# Altium schematic sheet stm32f7.SchDoc
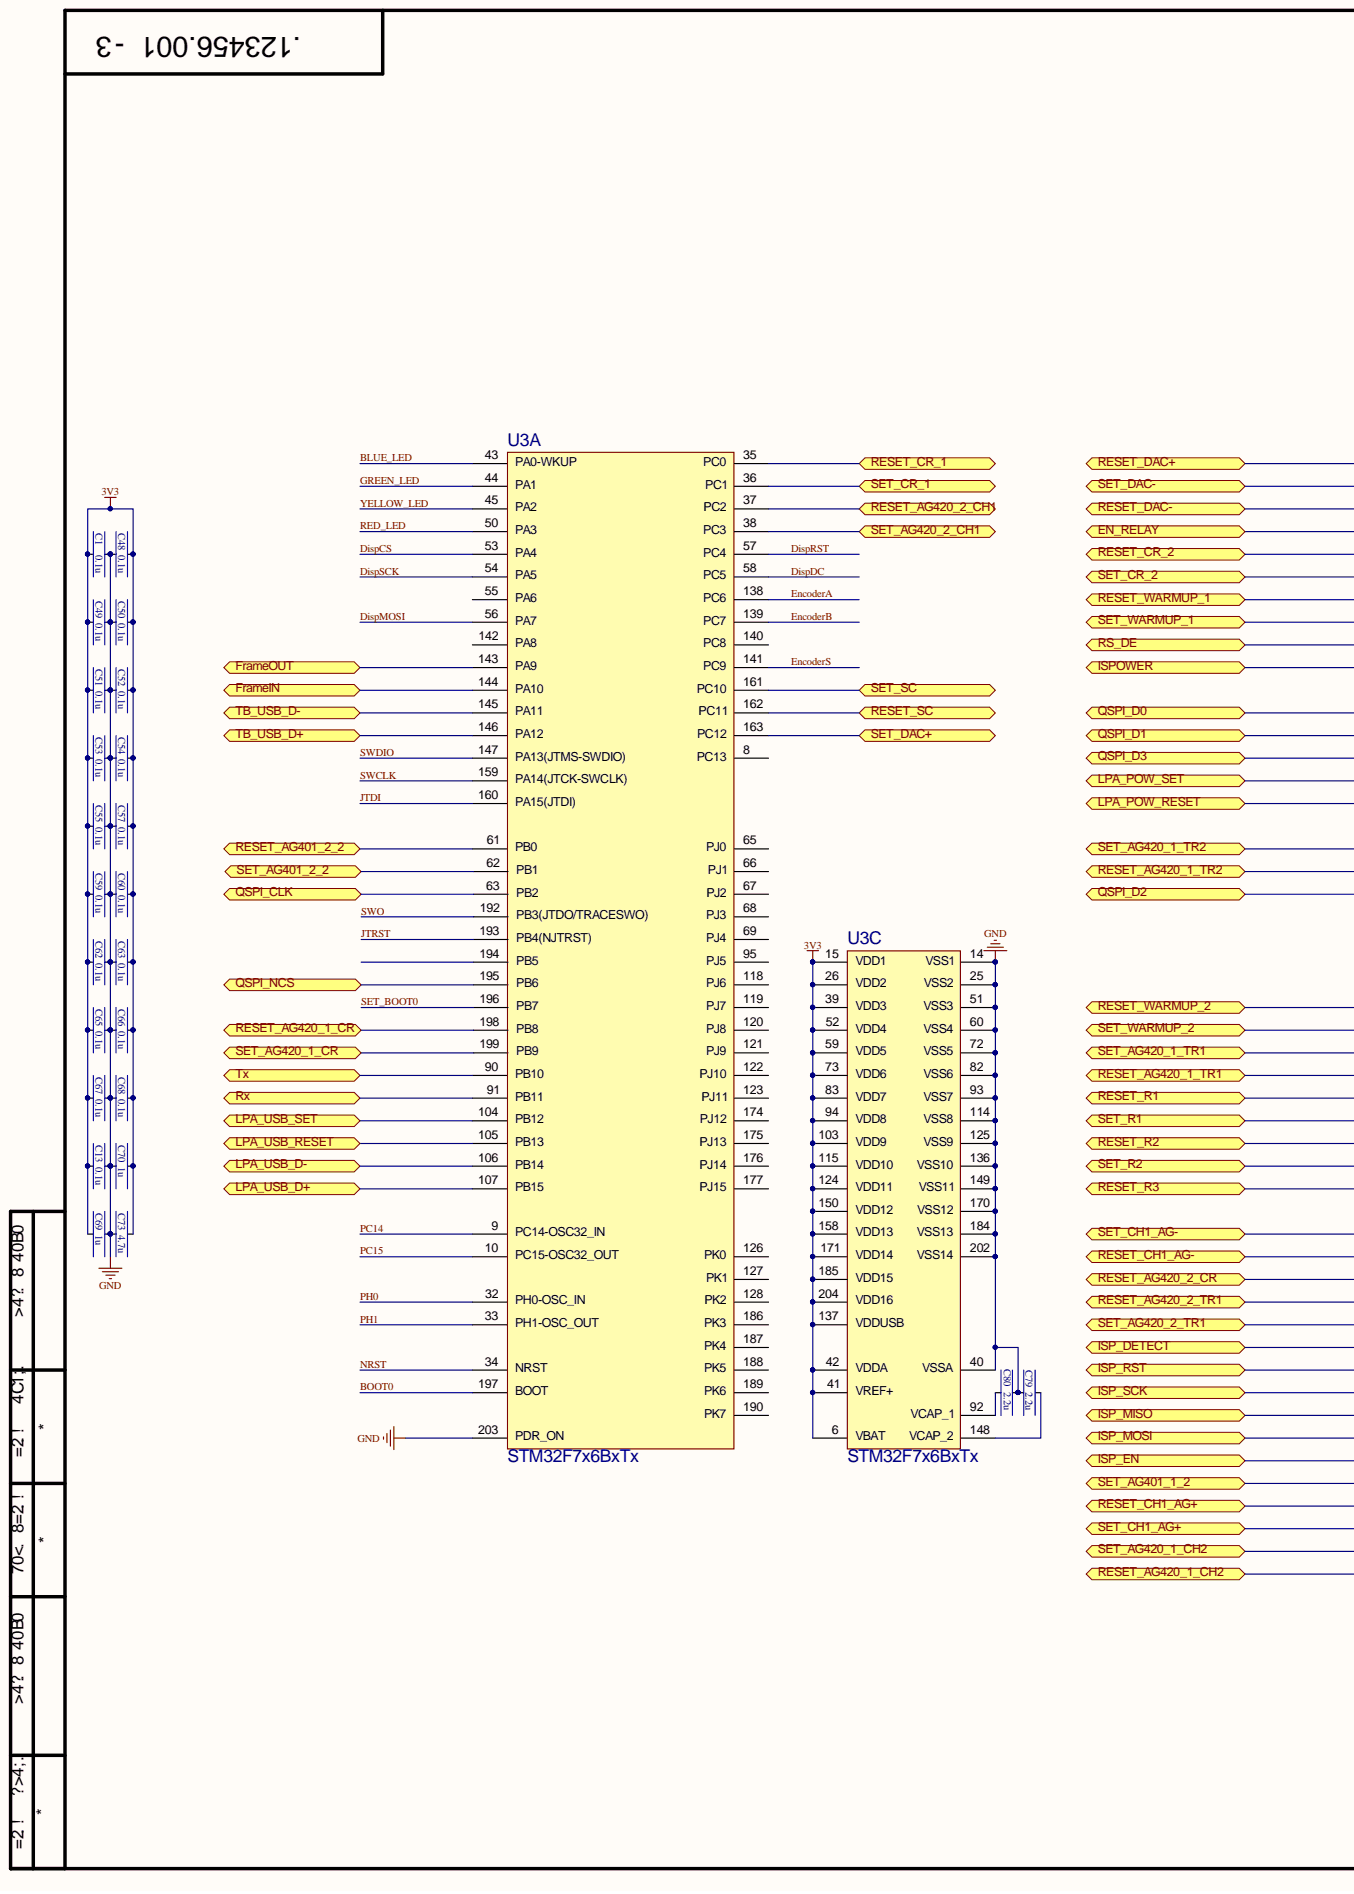
[diagram p0: stm32f7.SchDoc, left]
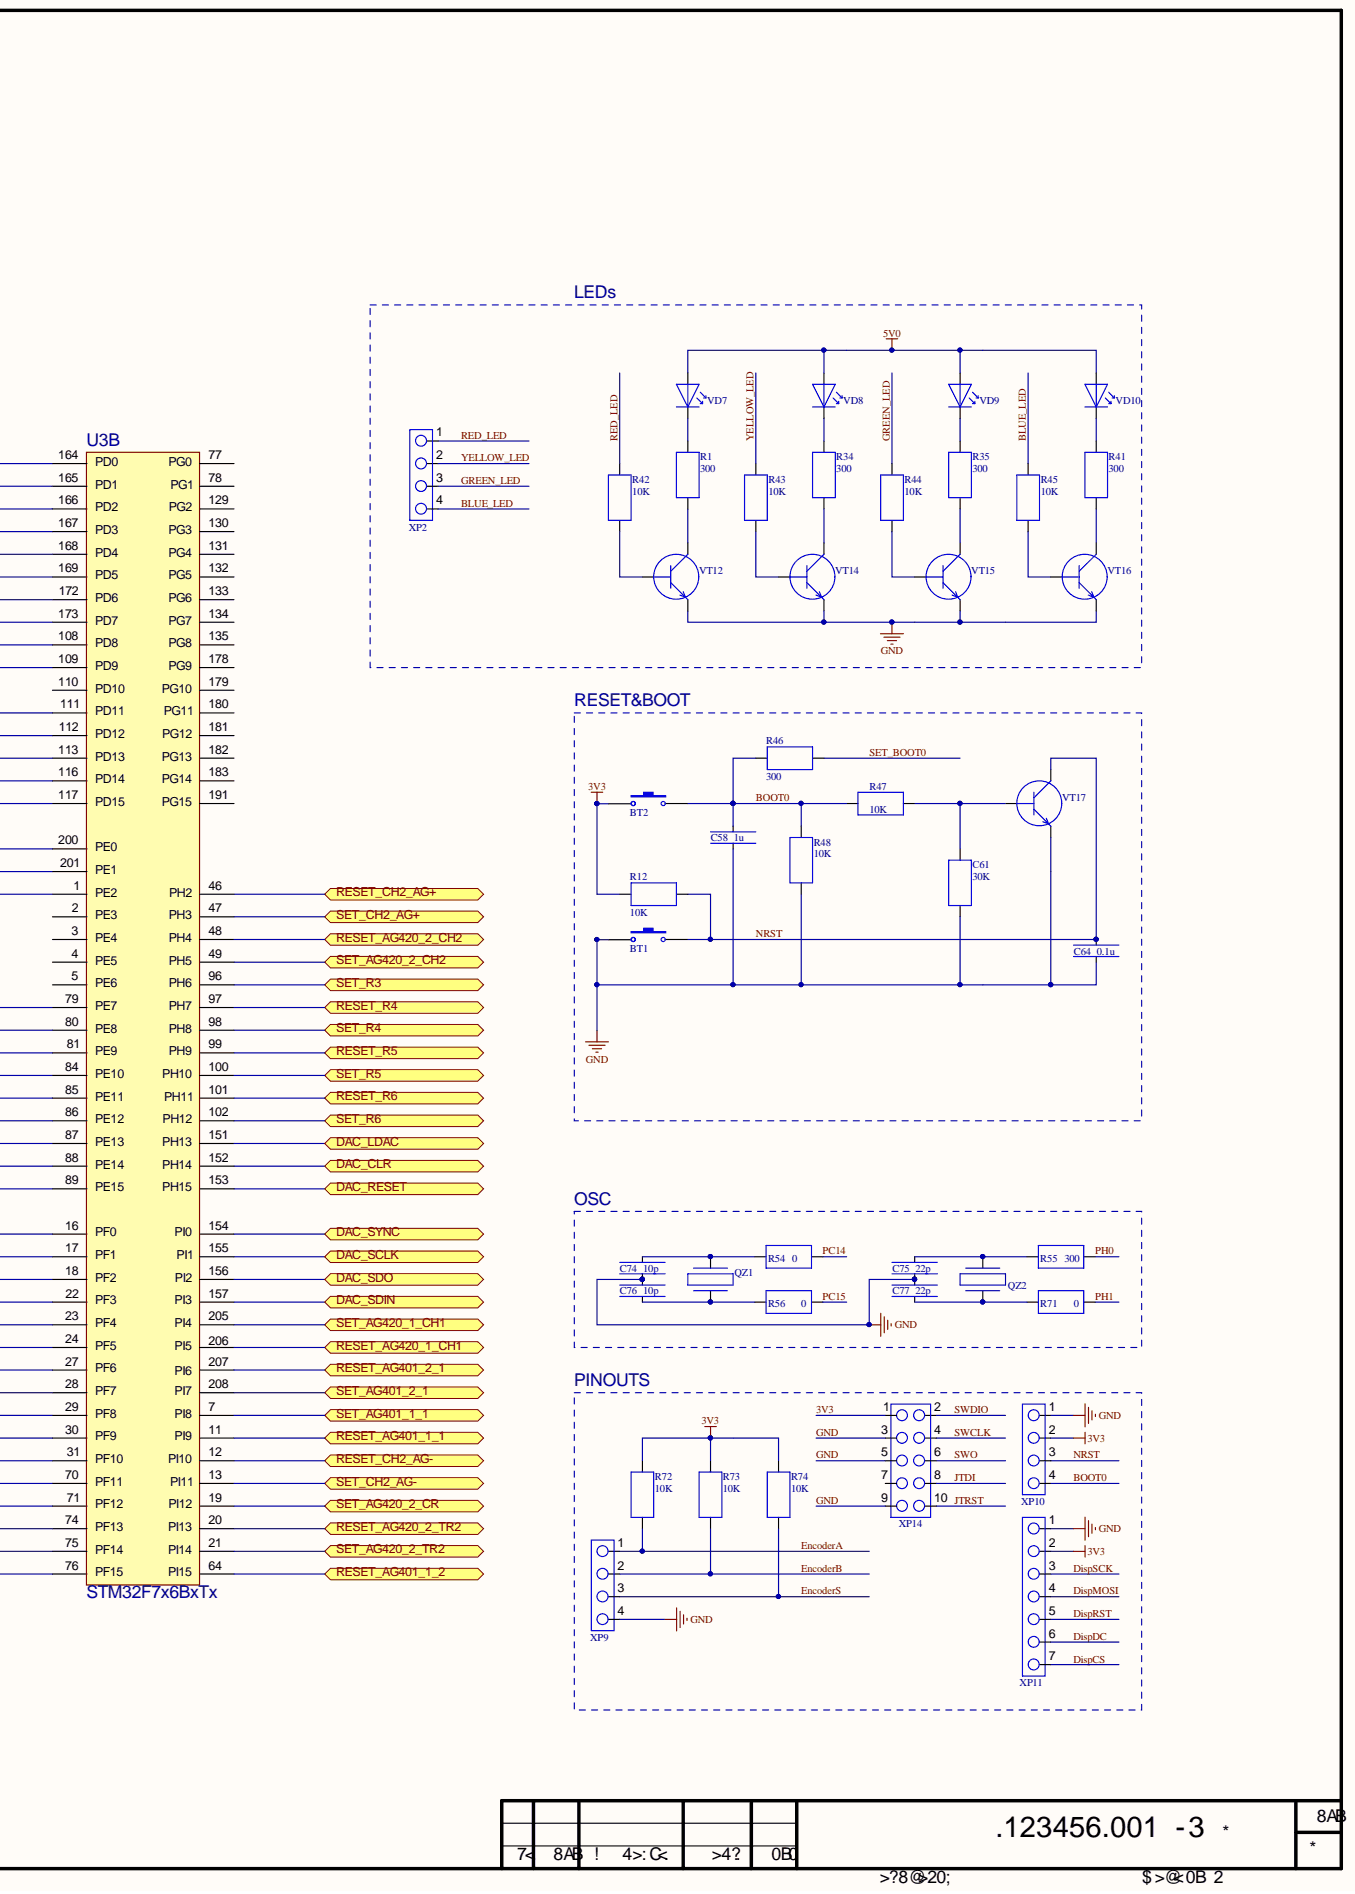
[diagram p1: stm32f7.SchDoc, right]

## Parts
BT1  Button  [TC-0206]  (value not drawn)
BT2  Button  [TC-0206]  (value not drawn)
C1  C  value 0.1u  [C_0603]
C13  C  value 0.1u  [C_0603]
C48  C  value 0.1u  [C_0603]
C49  C  value 0.1u  [C_0603]
C50  C  value 0.1u  [C_0603]
C51  C  value 0.1u  [C_0603]
C52  C  value 0.1u  [C_0603]
C53  C  value 0.1u  [C_0603]
C54  C  value 0.1u  [C_0603]
C55  C  value 0.1u  [C_0603]
C57  C  value 0.1u  [C_0603]
C58  C  value 1u  [C_0805]
C59  C  value 0.1u  [C_0603]
C60  C  value 0.1u  [C_0603]
C62  C  value 0.1u  [C_0603]
C63  C  value 0.1u  [C_0603]
C64  C  value 0.1u  [C_0805]
C65  C  value 0.1u  [C_0603]
C66  C  value 0.1u  [C_0603]
C67  C  value 0.1u  [C_0603]
C68  C  value 0.1u  [C_0603]
C69  C  value 1u  [C_0805]
C70  C  value 1u  [C_0805]
C73  C  value 4.7u  [C_0805]
C74  C  value 10p  [C_0805]
C75  C  value 22p  [C_0805]
C76  C  value 10p  [C_0805]
C77  C  value 22p  [C_0805]
C79  C  value 2.2u  [C_0805]
C80  C  value 2.2u  [C_0805]
QZ1  (no value)  [DT-38_SMD]
QZ2  KX-K(S)T  [KX-K(S)T]  (value not drawn)
R1  R  value 300  [R_0805]
R12  R  value 10K  [R_0805]
R34  R  value 300  [R_0805]
R35  R  value 300  [R_0805]
R41  R  value 300  [R_0805]
R42  R  value 10K  [R_0805]
R43  R  value 10K  [R_0805]
R44  R  value 10K  [R_0805]
R45  R  value 10K  [R_0805]
R46  R  value 300  [R_0805]
R47  R  value 10K  [R_0805]
R48  R  value 10K  [R_0805]
R54  R  value 0  [R_0805]
R55  R  value 300  [R_0805]
R56  R  value 0  [R_0805]
R71  R  value 0  [R_0805]
R72  R  value 10K  [R_0805]
R73  R  value 10K  [R_0805]
R74  R  value 10K  [R_0805]
U3  STM32F7x6BxTx  [LQFP208]  (parts A,B,C)
VD7  KPA3010  [KPA-3010_R]  (value not drawn)
VD8  KPA3010  [KPA-3010_Y]  (value not drawn)
VD9  KPA3010  [KPA-3010_G]  (value not drawn)
VD10  KPA3010  [KPA-3010_B]  (value not drawn)
VT12  BC847  [SOT23]  (value not drawn)
VT14  BC847  [SOT23]  (value not drawn)
VT15  BC847  [SOT23]  (value not drawn)
VT16  BC847  [SOT23]  (value not drawn)
VT17  BC847  [SOT23]  (value not drawn)
XP2  PLS-4  [PLS-4]  (value not drawn)
XP9  PLS-4  [PLS-4]  (value not drawn)
XP10  PLS-4  [PLS-4]  (value not drawn)
XP11  PLS-7  [PLS-7]  (value not drawn)
XP14  PLD-10  [PLD-10]  (value not drawn)
С61  value 30K  [C_0805]  (hidden comment: R)

## Ports
DAC_CLR, DAC_LDAC, DAC_RESET, DAC_SCLK, DAC_SDIN, DAC_SDO, DAC_SYNC, EN_RELAY, FrameIN, FrameOUT, ISP_DETECT, ISP_EN, ISP_MISO, ISP_MOSI, ISP_RST, ISP_SCK, ISPOWER, LPA_POW_RESET, LPA_POW_SET, LPA_USB_D+, LPA_USB_D-, LPA_USB_RESET, LPA_USB_SET, QSPI_CLK, QSPI_D0, QSPI_D1, QSPI_D2, QSPI_D3, QSPI_NCS, RESET_AG401_1_1, RESET_AG401_1_2, RESET_AG401_2_1, RESET_AG401_2_2, RESET_AG420_1_CH1, RESET_AG420_1_CH2, RESET_AG420_1_CR, RESET_AG420_1_TR1, RESET_AG420_1_TR2, RESET_AG420_2_CH1, RESET_AG420_2_CH2, RESET_AG420_2_CR, RESET_AG420_2_TR1, RESET_AG420_2_TR2, RESET_CH1_AG+, RESET_CH1_AG-, RESET_CH2_AG+, RESET_CH2_AG-, RESET_CR_1, RESET_CR_2, RESET_DAC+, RESET_DAC-, RESET_R1, RESET_R2, RESET_R3, RESET_R4, RESET_R5, RESET_R6, RESET_SC, RESET_WARMUP_1, RESET_WARMUP_2, RS_DE, Rx, SET_AG401_1_1, SET_AG401_1_2, SET_AG401_2_1, SET_AG401_2_2, SET_AG420_1_CH1, SET_AG420_1_CH2, SET_AG420_1_CR, SET_AG420_1_TR1, SET_AG420_1_TR2, SET_AG420_2_CH1, SET_AG420_2_CH2, SET_AG420_2_CR, SET_AG420_2_TR1, SET_AG420_2_TR2, SET_CH1_AG+, SET_CH1_AG-, SET_CH2_AG+, SET_CH2_AG-, SET_CR_1, SET_CR_2, SET_DAC+, SET_DAC-, SET_R1, SET_R2, SET_R3, SET_R4, SET_R5, SET_R6, SET_SC, SET_WARMUP_1, SET_WARMUP_2, TB_USB_D+, TB_USB_D-, Tx

## Nets
5V0: VD7.1 VD8.1 VD9.1 VD10.1
3V3: BT2.1 C1.2 C13.2 C48.1 C49.2 C50.1 C51.2 C52.1 C53.2 C54.1 C55.2 C57.1 C59.2 C60.1 C62.2 C63.1 C65.2 C66.1 C67.2 C68.1 C69.2 C70.1 C73.1 R12.2 R72.2 R73.2 R74.2 U3.6(VBAT) U3.15(VDD1) U3.26(VDD2) U3.39(VDD3) U3.41(VREF+) U3.42(VDDA) U3.52(VDD4) U3.59(VDD5) U3.73(VDD6) U3.83(VDD7) U3.94(VDD8) U3.103(VDD9) U3.115(VDD10) U3.124(VDD11) U3.137(VDDUSB) U3.150(VDD12) U3.158(VDD13) U3.171(VDD14) U3.185(VDD15) U3.204(VDD16) XP10.2 XP11.2 XP14.1
BLUE_LED: R45.2 U3.43(PA0-WKUP) XP2.4
BOOT0: BT2.2 C58.2 R46.2 R47.1 R48.2 U3.197(BOOT) XP10.4
DAC_CLR: U3.152(PH14) ; port DAC_CLR
DAC_LDAC: U3.151(PH13) ; port DAC_LDAC
DAC_RESET: U3.153(PH15) ; port DAC_RESET
DAC_SCLK: U3.155(PI1) ; port DAC_SCLK
DAC_SDIN: U3.157(PI3) ; port DAC_SDIN
DAC_SDO: U3.156(PI2) ; port DAC_SDO
DAC_SYNC: U3.154(PI0) ; port DAC_SYNC
DispCS: U3.53(PA4) XP11.7
DispDC: U3.58(PC5) XP11.6
DispMOSI: U3.56(PA7) XP11.4
DispRST: U3.57(PC4) XP11.5
DispSCK: U3.54(PA5) XP11.3
EN_RELAY: U3.167(PD3) ; port EN_RELAY
EncoderA: R72.1 U3.138(PC6) XP9.1
EncoderB: R73.1 U3.139(PC7) XP9.2
EncoderS: R74.1 U3.141(PC9) XP9.3
FrameIN: U3.144(PA10) ; port FrameIN
FrameOUT: U3.143(PA9) ; port FrameOUT
GND: BT1.1 C1.1 C13.1 C48.2 C49.1 C50.2 C51.1 C52.2 C53.1 C54.2 C55.1 C57.2 C58.1 C59.1 C60.2 C62.1 C63.2 C64.1 C65.1 C66.2 C67.1 C68.2 C69.1 C70.2 C73.2 C74.1 C75.1 C76.2 C77.2 C79.2 C80.1 R48.1 U3.14(VSS1) U3.25(VSS2) U3.40(VSSA) U3.51(VSS3) U3.60(VSS4) U3.72(VSS5) U3.82(VSS6) U3.93(VSS7) U3.114(VSS8) U3.125(VSS9) U3.136(VSS10) U3.149(VSS11) U3.170(VSS12) U3.184(VSS13) U3.202(VSS14) U3.203(PDR_ON) VT12.2 VT14.2 VT15.2 VT16.2 VT17.2 XP9.4 XP10.1 XP11.1 XP14.3 XP14.5 XP14.9 С61.1
GREEN_LED: R44.2 U3.44(PA1) XP2.3
ISP_DETECT: U3.24(PF5) ; port ISP_DETECT
ISP_EN: U3.31(PF10) ; port ISP_EN
ISP_MISO: U3.29(PF8) ; port ISP_MISO
ISP_MOSI: U3.30(PF9) ; port ISP_MOSI
ISP_RST: U3.27(PF6) ; port ISP_RST
ISP_SCK: U3.28(PF7) ; port ISP_SCK
ISPOWER: U3.109(PD9) ; port ISPOWER
JTDI: U3.160(PA15(JTDI)) XP14.8
JTRST: U3.193(PB4(NJTRST)) XP14.10
LPA_POW_RESET: U3.117(PD15) ; port LPA_POW_RESET
LPA_POW_SET: U3.116(PD14) ; port LPA_POW_SET
LPA_USB_D+: U3.107(PB15) ; port LPA_USB_D+
LPA_USB_D-: U3.106(PB14) ; port LPA_USB_D-
LPA_USB_RESET: U3.105(PB13) ; port LPA_USB_RESET
LPA_USB_SET: U3.104(PB12) ; port LPA_USB_SET
NRST: BT1.2 C64.2 R12.1 U3.34(NRST) VT17.3 XP10.3
PC14: R54.2 U3.9(PC14-OSC32_IN)
PC15: R56.2 U3.10(PC15-OSC32_OUT)
PH0: R55.2 U3.32(PH0-OSC_IN)
PH1: R71.2 U3.33(PH1-OSC_OUT)
QSPI_CLK: U3.63(PB2) ; port QSPI_CLK
QSPI_D0: U3.111(PD11) ; port QSPI_D0
QSPI_D1: U3.112(PD12) ; port QSPI_D1
QSPI_D2: U3.1(PE2) ; port QSPI_D2
QSPI_D3: U3.113(PD13) ; port QSPI_D3
QSPI_NCS: U3.195(PB6) ; port QSPI_NCS
RED_LED: R42.2 U3.50(PA3) XP2.1
RESET_AG401_1_1: U3.11(PI9) ; port RESET_AG401_1_1
RESET_AG401_1_2: U3.64(PI15) ; port RESET_AG401_1_2
RESET_AG401_2_1: U3.207(PI6) ; port RESET_AG401_2_1
RESET_AG401_2_2: U3.61(PB0) ; port RESET_AG401_2_2
RESET_AG420_1_CH1: U3.206(PI5) ; port RESET_AG420_1_CH1
RESET_AG420_1_CH2: U3.76(PF15) ; port RESET_AG420_1_CH2
RESET_AG420_1_CR: U3.198(PB8) ; port RESET_AG420_1_CR
RESET_AG420_1_TR1: U3.84(PE10) ; port RESET_AG420_1_TR1
RESET_AG420_1_TR2: U3.201(PE1) ; port RESET_AG420_1_TR2
RESET_AG420_2_CH1: U3.37(PC2) ; port RESET_AG420_2_CH1
RESET_AG420_2_CH2: U3.48(PH4) ; port RESET_AG420_2_CH2
RESET_AG420_2_CR: U3.18(PF2) ; port RESET_AG420_2_CR
RESET_AG420_2_TR1: U3.22(PF3) ; port RESET_AG420_2_TR1
RESET_AG420_2_TR2: U3.20(PI13) ; port RESET_AG420_2_TR2
RESET_CH1_AG+: U3.71(PF12) ; port RESET_CH1_AG+
RESET_CH1_AG-: U3.17(PF1) ; port RESET_CH1_AG-
RESET_CH2_AG+: U3.46(PH2) ; port RESET_CH2_AG+
RESET_CH2_AG-: U3.12(PI10) ; port RESET_CH2_AG-
RESET_CR_1: U3.35(PC0) ; port RESET_CR_1
RESET_CR_2: U3.168(PD4) ; port RESET_CR_2
RESET_DAC+: U3.164(PD0) ; port RESET_DAC+
RESET_DAC-: U3.166(PD2) ; port RESET_DAC-
RESET_R1: U3.85(PE11) ; port RESET_R1
RESET_R2: U3.87(PE13) ; port RESET_R2
RESET_R3: U3.89(PE15) ; port RESET_R3
RESET_R4: U3.97(PH7) ; port RESET_R4
RESET_R5: U3.99(PH9) ; port RESET_R5
RESET_R6: U3.101(PH11) ; port RESET_R6
RESET_SC: U3.162(PC11) ; port RESET_SC
RESET_WARMUP_1: U3.172(PD6) ; port RESET_WARMUP_1
RESET_WARMUP_2: U3.79(PE7) ; port RESET_WARMUP_2
RS_DE: U3.108(PD8) ; port RS_DE
Rx: U3.91(PB11) ; port Rx
SET_AG401_1_1: U3.7(PI8) ; port SET_AG401_1_1
SET_AG401_1_2: U3.70(PF11) ; port SET_AG401_1_2
SET_AG401_2_1: U3.208(PI7) ; port SET_AG401_2_1
SET_AG401_2_2: U3.62(PB1) ; port SET_AG401_2_2
SET_AG420_1_CH1: U3.205(PI4) ; port SET_AG420_1_CH1
SET_AG420_1_CH2: U3.75(PF14) ; port SET_AG420_1_CH2
SET_AG420_1_CR: U3.199(PB9) ; port SET_AG420_1_CR
SET_AG420_1_TR1: U3.81(PE9) ; port SET_AG420_1_TR1
SET_AG420_1_TR2: U3.200(PE0) ; port SET_AG420_1_TR2
SET_AG420_2_CH1: U3.38(PC3) ; port SET_AG420_2_CH1
SET_AG420_2_CH2: U3.49(PH5) ; port SET_AG420_2_CH2
SET_AG420_2_CR: U3.19(PI12) ; port SET_AG420_2_CR
SET_AG420_2_TR1: U3.23(PF4) ; port SET_AG420_2_TR1
SET_AG420_2_TR2: U3.21(PI14) ; port SET_AG420_2_TR2
SET_BOOT0: R46.1 U3.196(PB7)
SET_CH1_AG+: U3.74(PF13) ; port SET_CH1_AG+
SET_CH1_AG-: U3.16(PF0) ; port SET_CH1_AG-
SET_CH2_AG+: U3.47(PH3) ; port SET_CH2_AG+
SET_CH2_AG-: U3.13(PI11) ; port SET_CH2_AG-
SET_CR_1: U3.36(PC1) ; port SET_CR_1
SET_CR_2: U3.169(PD5) ; port SET_CR_2
SET_DAC+: U3.163(PC12) ; port SET_DAC+
SET_DAC-: U3.165(PD1) ; port SET_DAC-
SET_R1: U3.86(PE12) ; port SET_R1
SET_R2: U3.88(PE14) ; port SET_R2
SET_R3: U3.96(PH6) ; port SET_R3
SET_R4: U3.98(PH8) ; port SET_R4
SET_R5: U3.100(PH10) ; port SET_R5
SET_R6: U3.102(PH12) ; port SET_R6
SET_SC: U3.161(PC10) ; port SET_SC
SET_WARMUP_1: U3.173(PD7) ; port SET_WARMUP_1
SET_WARMUP_2: U3.80(PE8) ; port SET_WARMUP_2
SWCLK: U3.159(PA14(JTCK-SWCLK)) XP14.4
SWDIO: U3.147(PA13(JTMS-SWDIO)) XP14.2
SWO: U3.192(PB3(JTDO/TRACESWO)) XP14.6
TB_USB_D+: U3.146(PA12) ; port TB_USB_D+
TB_USB_D-: U3.145(PA11) ; port TB_USB_D-
Tx: U3.90(PB10) ; port Tx
YELLOW_LED: R43.2 U3.45(PA2) XP2.2
NetC74_2: C74.2 QZ1.2 R54.1
NetC75_2: C75.2 QZ2.1 R55.1
NetC76_1: C76.1 QZ1.1 R56.1
NetC77_1: C77.1 QZ2.2 R71.1
NetC79_1: C79.1 U3.148(VCAP_2)
NetC80_2: C80.2 U3.92(VCAP_1)
NetR1_1: R1.1 VT12.3
NetR1_2: R1.2 VD7.2
NetR34_1: R34.1 VT14.3
NetR34_2: R34.2 VD8.2
NetR35_1: R35.1 VT15.3
NetR35_2: R35.2 VD9.2
NetR41_1: R41.1 VT16.3
NetR41_2: R41.2 VD10.2
NetR42_1: R42.1 VT12.1
NetR43_1: R43.1 VT14.1
NetR44_1: R44.1 VT15.1
NetR45_1: R45.1 VT16.1
NetR47_2: R47.2 VT17.1 С61.2

Not connected: U3 2(PE3) 3(PE4) 4(PE5) 5(PE6) 8(PC13) 55(PA6) 65(PJ0) 66(PJ1) 67(PJ2) 68(PJ3) 69(PJ4) 77(PG0) 78(PG1) 95(PJ5) 110(PD10) 118(PJ6) 119(PJ7) 120(PJ8) 121(PJ9) 122(PJ10) 123(PJ11) 126(PK0) 127(PK1) 128(PK2) 129(PG2) 130(PG3) 131(PG4) 132(PG5) 133(PG6) 134(PG7) 135(PG8) 140(PC8) 142(PA8) 174(PJ12) 175(PJ13) 176(PJ14) 177(PJ15) 178(PG9) 179(PG10) 180(PG11) 181(PG12) 182(PG13) 183(PG14) 186(PK3) 187(PK4) 188(PK5) 189(PK6) 190(PK7) 191(PG15) 194(PB5); XP14 7

## Library data of the parts
C1 C13 C48-C55 C57-C60 C62-C70 C73-C77 C79 C80 = C: Конденсатор; Component: Конденсатор; Component Kind: Standard; Library Name: All_design_lib.SchLib
QZ1 = Crystal Resonator: Component Kind: Standard; Description: Crystal Resonator; Library Name: All_design_lib.SchLib
QZ2 = KX-K(S)T: Кварцевый резонатор; Наименование: Кварцевый резонатор KX-KST 8 МГц; Component Kind: Standard; Library Name: All_design_lib.SchLib
R1 R12 R34 R35 R41-R48 R54-R56 R71-R74 С61 = R: Резистор; Component: Резистор; Component Kind: Standard; Library Name: All_design_lib.SchLib
U3 = STM32F7x6BxTx: ARM32 High Performance MCU; Component Kind: Standard; Library Name: All_design_lib.SchLib
VD7-VD10 = KPA3010: Светодиод планарный боковой; Component Kind: Standard; Library Name: All_design_lib.SchLib
BT1 BT2 = Button: Component Kind: Standard; Library Name: All_design_lib.SchLib
VT12 VT14-VT17 = BC847: Component Kind: Standard; Description: BC847; Library Name: All_design_lib.SchLib
XP2 XP9 XP10 = PLS-4: Component Kind: Standard; Description: PLS-4
  XP2 XP9: Library Name: All_design_lib.SchLib
  XP10: Library Name: All_design_lib.IntLib
XP11 = PLS-7: Component Kind: Standard; Description: PLS-7; Library Name: All_design_lib.SchLib
XP14 = PLD-10: Component Kind: Standard; Library Name: All_design_lib.SchLib
